# Altium schematic sheet S7CAS-PLEIADES-CARTE-MERE_P07_MOT.SchDoc(S7CAS-PLEIADES-CARTE-MERE_P07_MOT)
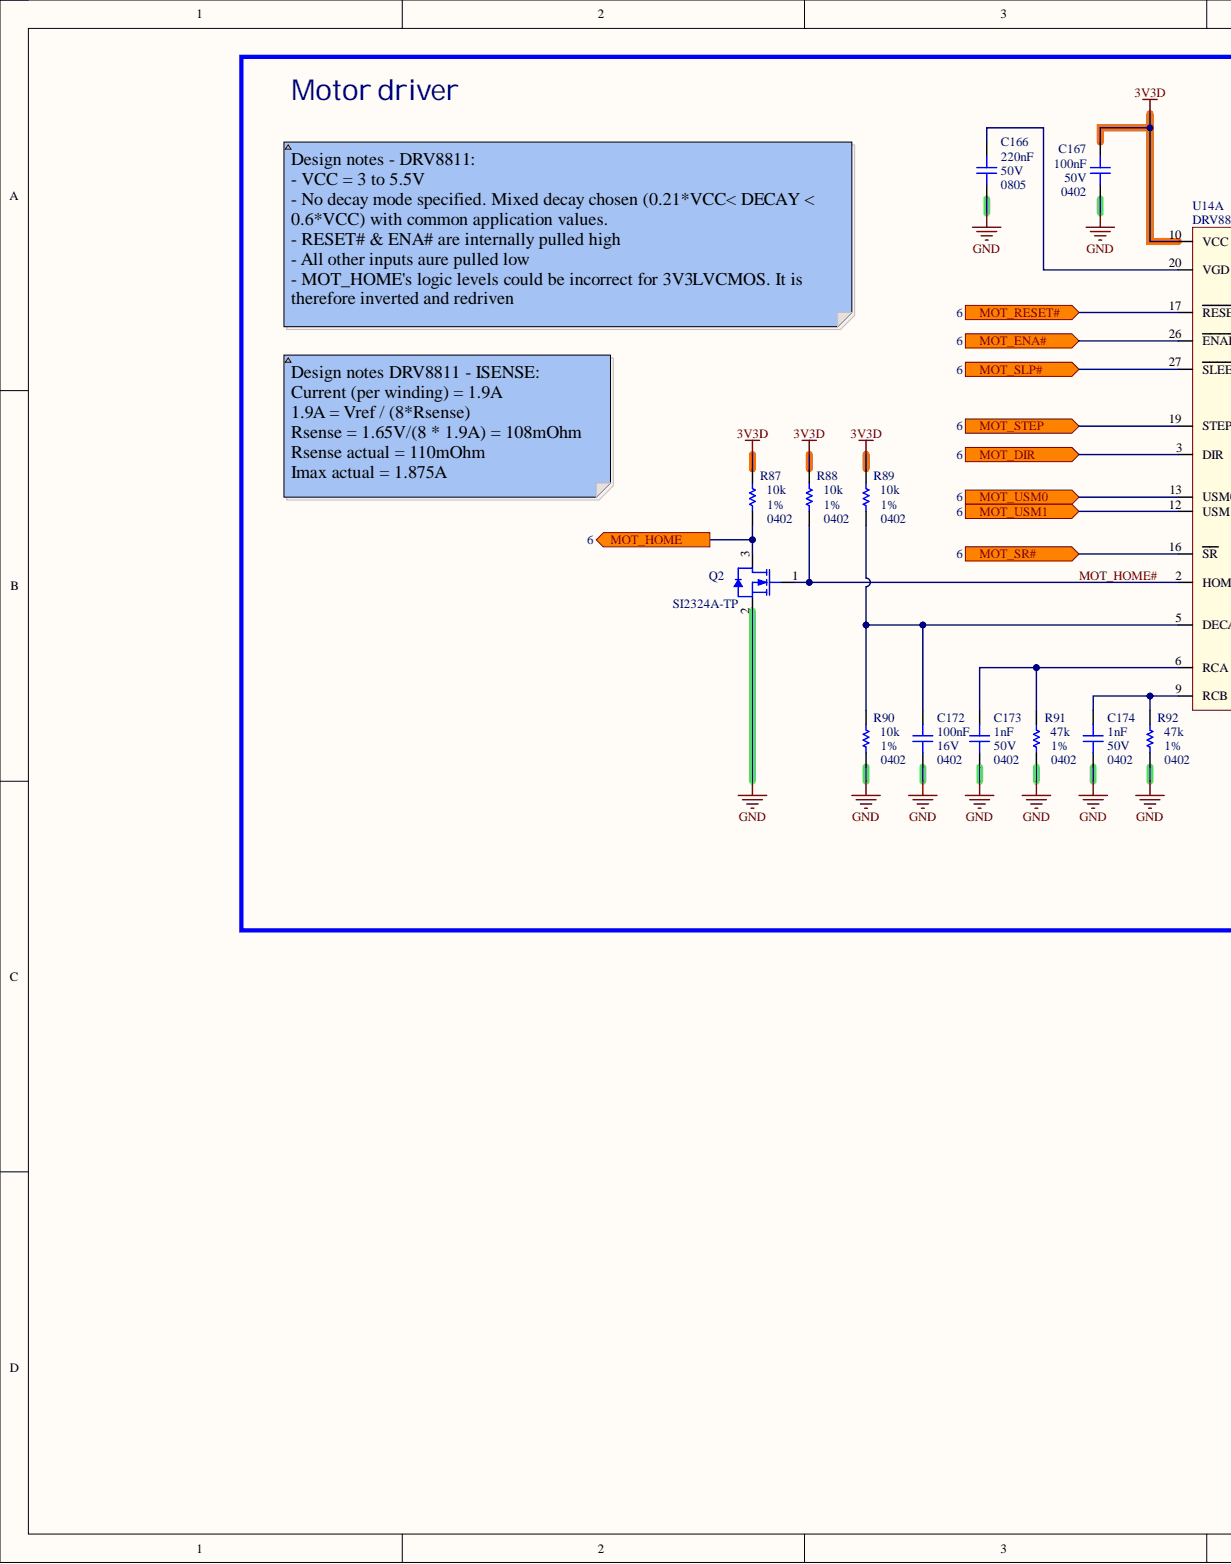
[diagram p0: S7CAS-PLEIADES-CARTE-MERE_P07_MOT.SchDoc, left]
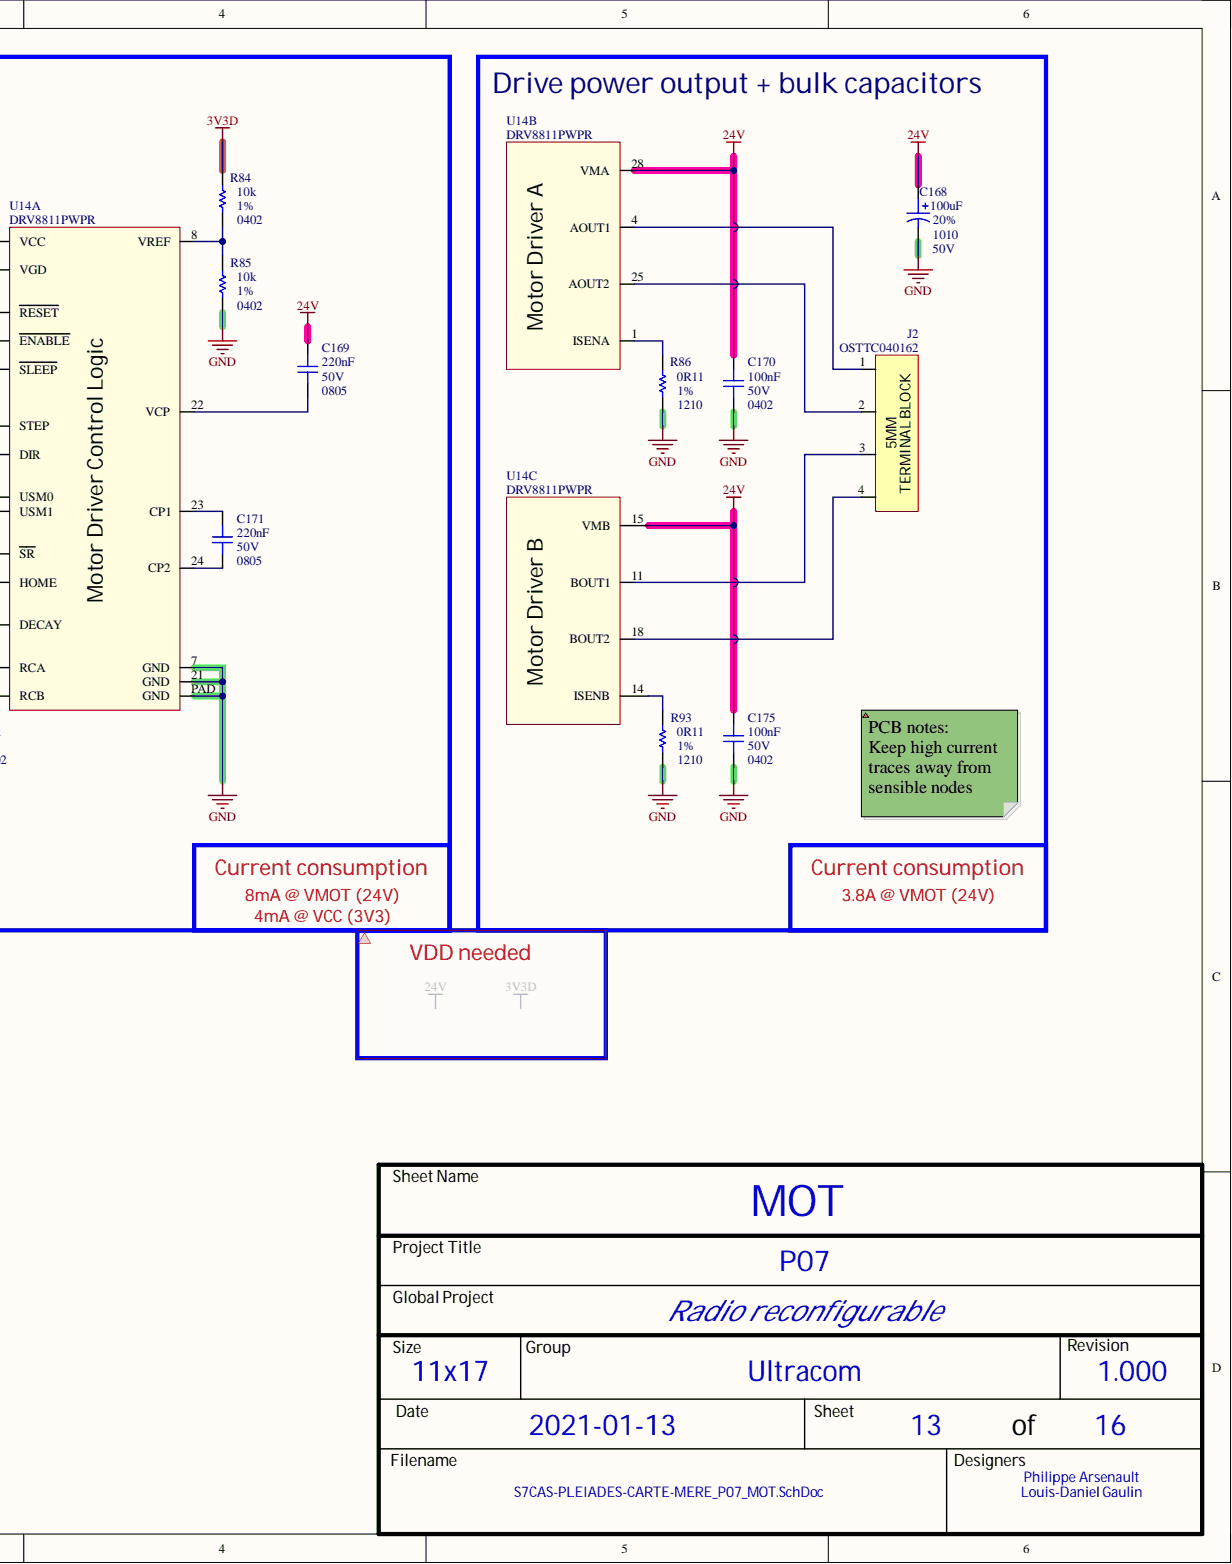
[diagram p1: S7CAS-PLEIADES-CARTE-MERE_P07_MOT.SchDoc, right]

## Parts
C166  value 220nF  Package: 0805  Voltage: 50V  [CAP_0805]  (hidden comment: CAP - 0805)
C167  value 100nF  Package: 0402  Voltage: 50V  [CAP_0402]  (hidden comment: CAP - 0402)
C168  value 100uF  Package: 1010  Precision: 20%  Voltage: 50V  [CAP_ALU_1010_vishay]  (hidden comment: MAL215099101E3)
C169  value 220nF  Package: 0805  Voltage: 50V  [CAP_0805]  (hidden comment: CAP - 0805)
C170  value 100nF  Package: 0402  Voltage: 50V  [CAP_0402]  (hidden comment: CAP - 0402)
C171  value 220nF  Package: 0805  Voltage: 50V  [CAP_0805]  (hidden comment: CAP - 0805)
C172  value 100nF  Package: 0402  Voltage: 16V  [CAP_0402]  (hidden comment: CAP - 0402)
C173  value 1nF  Package: 0402  Voltage: 50V  [CAP_0402]  (hidden comment: CAP - 0402)
C174  value 1nF  Package: 0402  Voltage: 50V  [CAP_0402]  (hidden comment: CAP - 0402)
C175  value 100nF  Package: 0402  Voltage: 50V  [CAP_0402]  (hidden comment: CAP - 0402)
J2  OSTTC040162  [CONN_TERMINAL_OSTTA040161]
Q2  SI2324A-TP  [TRX_NMOS_SI2310-TP]
R84  value 10k  Package: 0402  Precision: 1%  [RES_0402]  (hidden comment: RES - 0402)
R85  value 10k  Package: 0402  Precision: 1%  [RES_0402]  (hidden comment: RES - 0402)
R86  value 0R11  Package: 1210  Precision: 1%  [RES_1210]  (hidden comment: RES - 1210)
R87  value 10k  Package: 0402  Precision: 1%  [RES_0402]  (hidden comment: RES - 0402)
R88  value 10k  Package: 0402  Precision: 1%  [RES_0402]  (hidden comment: RES - 0402)
R89  value 10k  Package: 0402  Precision: 1%  [RES_0402]  (hidden comment: RES - 0402)
R90  value 10k  Precision: 1%  [RES_0402]  (hidden comment: RES - 0402)
R91  value 47k  Precision: 1%  [RES_0402]  (hidden comment: RES - 0402)
R92  value 47k  Package: 0402  Precision: 1%  [RES_0402]  (hidden comment: RES - 0402)
R93  value 0R11  Package: 1210  Precision: 1%  [RES_1210]  (hidden comment: RES - 1210)
U14  DRV8811PWPR  [POW_MOTOR_DRV8811PWPR]  (parts A,B,C)

## Ports
MOT_DIR, MOT_ENA#, MOT_HOME, MOT_RESET#, MOT_SLP#, MOT_SR#, MOT_STEP, MOT_USM0, MOT_USM1

## Nets
3V3D: C167.2 R84.2 R87.2 R88.2 R89.2 U14.10(VCC)
24V: C168.1 C169.2 C170.2 C175.2 U14.15(VMB) U14.28(VMA)
GND: C166.2 C167.1 C168.2 C170.1 C172.1 C173.1 C174.1 C175.1 Q2.2 R85.1 R86.1 R90.1 R91.1 R92.1 R93.1 U14.7(GND) U14.21(GND) U14.PAD(GND)
MOT_DIR: U14.3(DIR) ; port MOT_DIR
MOT_ENA#: U14.26(ENABLE) ; port MOT_ENA#
MOT_HOME: Q2.3 R87.1 ; port MOT_HOME
MOT_HOME#: Q2.1 R88.1 U14.2(HOME)
MOT_RESET#: U14.17(RESET) ; port MOT_RESET#
MOT_SLP#: U14.27(SLEEP) ; port MOT_SLP#
MOT_SR#: U14.16(SR) ; port MOT_SR#
MOT_STEP: U14.19(STEP) ; port MOT_STEP
MOT_USM0: U14.13(USM0) ; port MOT_USM0
MOT_USM1: U14.12(USM1) ; port MOT_USM1
NetC166_1: C166.1 U14.20(VGD)
NetC169_1: C169.1 U14.22(VCP)
NetC171_1: C171.1 U14.24(CP2)
NetC171_2: C171.2 U14.23(CP1)
NetC172_2: C172.2 R89.1 R90.2 U14.5(DECAY)
NetC173_2: C173.2 R91.2 U14.6(RCA)
NetC174_2: C174.2 R92.2 U14.9(RCB)
NetJ2_1: J2.1 U14.4(AOUT1)
NetJ2_2: J2.2 U14.25(AOUT2)
NetJ2_3: J2.3 U14.11(BOUT1)
NetJ2_4: J2.4 U14.18(BOUT2)
NetR84_1: R84.1 R85.2 U14.8(VREF)
NetR86_2: R86.2 U14.1(ISENA)
NetR93_2: R93.2 U14.14(ISENB)

## Library data of the parts
C166 C169 C171 = CAP - 0805: Mfg Part Number: CL21B224KBFNNNE; Precision: 10%; Component Kind: Standard; Description: CAP - 0805; Library Name: S7CAS_LIB2021.IntLib; CreatedBy: Jonathan Bouchard
C167 = CAP - 0402: Precision: 10%; Component Kind: Standard; Description: CAP - 0402; Library Name: S7CAS_LIB2021.IntLib; CreatedBy: Jonathan Bouchard
C168 = CAP_ALU_MAL215099101E3: CAP - ALU 100uF 20% 50V - 1010; Current: 490mA; Mfg: Vishay Dale; Component Kind: Standard; HelpURL: http://www.vishay.com/docs/28395/150crz.pdf; Library Name: S7CAS_LIB2021.IntLib; Mfg Part Number: MAL215099101E3; Supplier 1: Digikey; Supplier Part Number 1: 4399PHDKR-ND; Temperature: -55°C to 125°C; CreatedBy: Jonathan Bouchard
C170 C173-C175 = CAP - 0402: Mfg: C1005X7R1H102K050BA; Precision: 10%; Component Kind: Standard; Description: CAP - 0402; Library Name: S7CAS_LIB2021.IntLib; CreatedBy: Jonathan Bouchard
C172 = CAP - 0402: Mfg Part Number: CL05B104KO5NNNC; Precision: 10%; Component Kind: Standard; Description: CAP - 0402; Library Name: S7CAS_LIB2021.IntLib; CreatedBy: Jonathan Bouchard
J2 = CONN_TERMINAL_OSTTC040162: CONN -  TERMINAL BLOCK 4POS 5MM - TH; Mfg: On Shore Technology Inc.; Component Kind: Standard; HelpURL: http://www.on-shore.com/wp-content/uploads/2015/09/osttcxx0162.pdf; Library Name: S7CAS_LIB2021.IntLib; Mfg Part Number: OSTTC040162; Package: TH-4; Supplier 1: Digikey; Supplier Part Number 1: ED2602-ND; Temperature: -30°C ~ 105°C; CreatedBy: Jonathan Bouchard
Q2 = TRX_NMOS_SI2324A-TP: TRX - MOSFET N-CH 100V 2A - SOT-23; Manufacturer #1: Micro Commercial Co; Magnetic Material: UNKNOWN; Polarized: Yes; Power Max: 350mW; Voltage rating: 100V (Vds max), 2V (Vgs @ 250uA); Assembly Type: SMD; Component Kind: Standard; Current Rating: 2A; HelpURL: https://www.mccsemi.com/pdf/Products/SI2324A(SOT-23)-A.pdf; Library Name: S7CAS_P07.IntLib; Package: SOT-23; Part Number #1: SI2324A-TP; Supplier 1: Digi-Key; Supplier Part Number 1: SI2324A-TPMSCT-ND; Temperature: -55°C ~ 150°C (TJ)
R84 R85 R87-R92 = RES - 0402: Power: 1/16W; Voltage: 50V; Component Kind: Standard; Description: RES - 0402; Library Name: S7CAS_LIB2021.IntLib; CreatedBy: Jonathan Bouchard
  R90 R91: Package: 0402
R86 R93 = RES - 1210: Power: 1/16W; Component Kind: Standard; Description: RES - 1210; Library Name: S7CAS_LIB2021.IntLib; CreatedBy: Jonathan Bouchard
U14 = POW_MOTOR_DRV8811PWPR: POW - STEPPER MOTOR DRIVER - SOP-28; Mfg: Texas Instrument; Voltage: 3 V ~ 5.5 V / 8 V ~ 38 V; Component Kind: Standard; HelpURL: http://www.ti.com/lit/ds/symlink/drv8811.pdf; Library Name: S7CAS_LIB2021.IntLib; Mfg Part Number: DRV8811PWPR; Package: SOP-28; Supplier 1: Digikey; Supplier Part Number 1: 296-24591-1-ND; Temperature: -40°C ~ 150°C (TJ); CreatedBy: Jonathan Bouchard
